# Altium schematic sheet Power.SchDoc
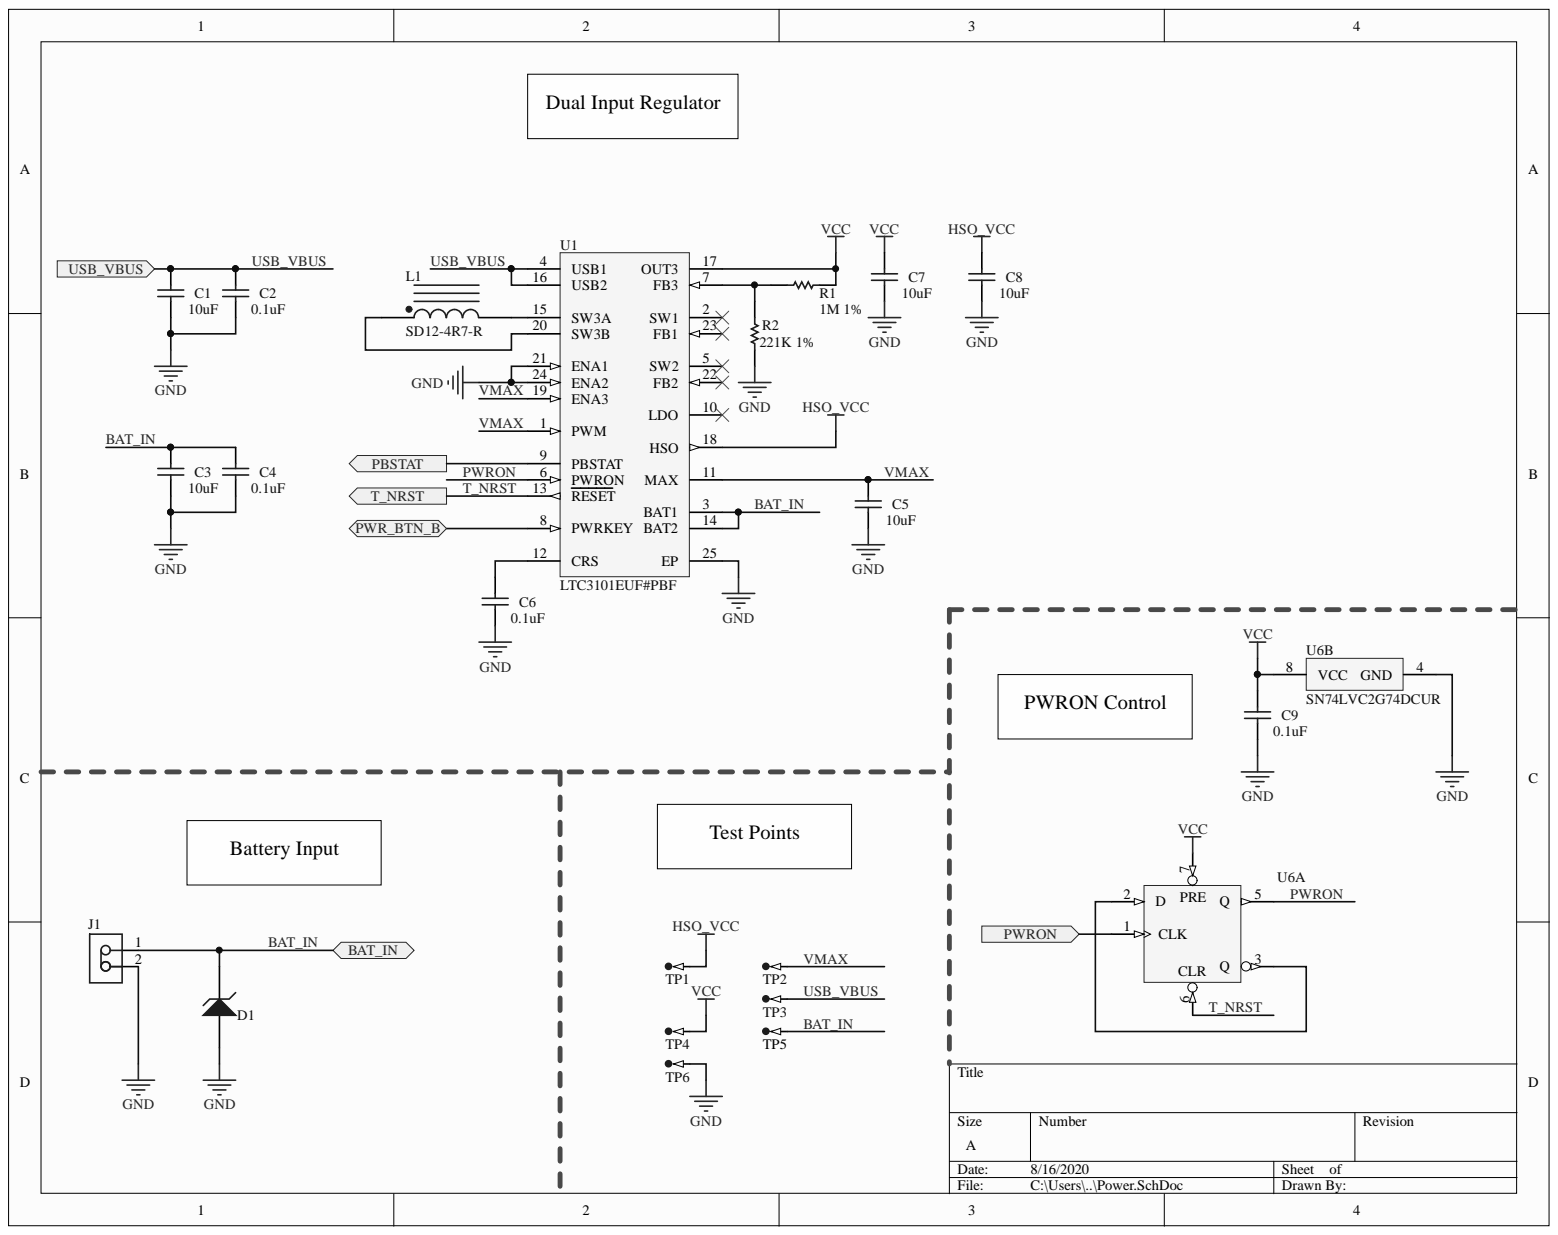
[diagram p0: Power.SchDoc]

## Parts
C1  10uF  [CAPC0603(1608)100_L]
C2  0.1uF  [CAPC0603(1608)100_L]
C3  10uF  [CAPC0603(1608)100_L]
C4  0.1uF  [CAPC0603(1608)100_L]
C5  10uF  [CAPC0603(1608)100_L]
C6  0.1uF  [CAPC0603(1608)100_L]
C7  10uF  [CAPC0603(1608)100_L]
C8  10uF  [CAPC0603(1608)100_L]
C9  0.1uF  [CAPC0603(1608)100_L]
D1  XGD10603MR  [TECO-0603_V]  (value not drawn)
J1  640456-2  [TECO-640456-2_V]  (value not drawn)
L1  SD12-4R7-R  [EATN-SD12_V]
R1  1M 1%  [RESC0603(1608)_N]
R2  221K 1%  [RESC0603(1608)_N]
TP1  TP-SM-C-100  [TP-SM-C-100]  (value not drawn)  (no BOM)
TP2  TP-SM-C-100  [TP-SM-C-100]  (value not drawn)  (no BOM)
TP3  TP-SM-C-100  [TP-SM-C-100]  (value not drawn)  (no BOM)
TP4  TP-SM-C-100  [TP-SM-C-100]  (value not drawn)  (no BOM)
TP5  TP-SM-C-100  [TP-SM-C-100]  (value not drawn)  (no BOM)
TP6  TP-SM-C-100  [TP-SM-C-100]  (value not drawn)  (no BOM)
U1  LTC3101EUF#PBF  [LT-UF-24_N]
U6  SN74LVC2G74DCUR  [DCU0008A_N]  (parts A,B)

## Ports
BAT_IN, PBSTAT, PWR_BTN_B, PWRON, T_NRST, USB_VBUS

## Nets
GND: C1.1 C2.2 C3.1 C4.2 C5.1 C6.2 C7.1 C8.1 C9.2 D1.1 J1.2 R2.1 TP6.1 U1.21(ENA1) U1.24(ENA2) U1.25(EP) U6.4(GND)
HSO_VCC: C8.2 TP1.1 U1.18(HSO)
VCC: C7.2 C9.1 R1.1 TP4.1 U1.17(OUT3) U6.7 U6.8(VCC)
BAT_IN: C3.2 C4.1 D1.2 J1.1 TP5.1 U1.3(BAT1) U1.14(BAT2) ; port BAT_IN
PBSTAT: U1.9(PBSTAT) ; port PBSTAT
PWR_BTN_B: U1.8(PWRKEY) ; port PWR_BTN_B
PWRON: U1.6(PWRON) U6.5(Q)
T_NRST: U1.13(RESET) U6.6 ; port T_NRST
USB_VBUS: C1.2 C2.1 TP3.1 U1.4(USB1) U1.16(USB2) ; port USB_VBUS
VMAX: C5.2 TP2.1 U1.1(PWM) U1.11(MAX) U1.19(ENA3)
NetC6_1: C6.1 U1.12(CRS)
NetL1_1: L1.1 U1.20(SW3B)
NetL1_2: L1.2 U1.15(SW3A)
NetR1_2: R1.2 R2.2 U1.7(FB3)
NetU1_2: U1.2(SW1)
NetU1_5: U1.5(SW2)
NetU1_10: U1.10(LDO)
NetU1_22: U1.22(FB2)
NetU1_23: U1.23(FB1)
NetU6_1: U6.1(CLK) ; port PWRON
NetU6_2: U6.2(D) U6.3(Q)

## Library data of the parts
C1-C9 = CMP-1035-00873-1: CAP 27pF 16V ±0.5pF 0603 (1608 Metric) Thickness 1mm SMD; Case-EIA: 0603; Case-Metric: 1608; Tolerance: Â±0.5pF; Component Kind: Standard; Library Name: Altium Content Vault; Max Thickness: 1 mm; Rated Voltage: 16 V; Technology: SMT; Value: 27pF
D1 = CMP-2000-06889-1: ESD Protector Overvoltage Protection Device, 0.25 pF, 24 V, -55 to 125 degC, 2-Pin SMD (0603), RoHS, Tape and Reel; Manufacturer: TE Connectivity; Capacitance Value: 0.25pF; Clamping Voltage: 45(Typ)V; Direction Type: Bi-Directional; Leakage Current: 10uA; Number of  Elements: 1; Product Depth (mm): 1(Max)mm; Product Height (mm): 0.55mm; Product Length (mm): 1.7(Max)mm; Rad Hardened: No; Reverse Stand-off Voltage: 24V; Component Kind: Standard; Datasheet URL: https://datasheet.ciiva.com/30563/eng-ss-scd27256-c-30563476.pdf; Datasheet Version: Rev. G, 11/2012; Leakage Current (Max): 10uA; Library Name: Altium Content Vault; Manufacturer URL: http://www.te.com/; Mounting: SurfaceMount; Mounting Style: SurfaceMount; Mounting Technology: SurfaceMount; Operating Temperature Classification: Military; Operating Temperature Max Deg. C: 125C; Operating Temperature Min Deg. C: -55C; Operating Temp Range: -55Cto125C; Package Description: 2-Pin Surface Mount Device, Body 1.60 x 0.825 mm; Package Reference: 0603; Packaging: TapeandReel/Box; Pin Count: 2; Working Voltage: 24V; Working Voltage (Max): 24V
J1 = CMP-2000-05417-1: Male Header, Pitch 2.54 mm, 1 x 2 Position, Height 10.49 mm, Tail Length 3.556 mm, -55 to 105 degC, RoHS, Bulk; Manufacturer: TE Connectivity; Agency Approvals: CSA,UL; Angle: Straight; Brand/Series: MTA-100Series; Color, Housing: White; Connector Type: MTA-100; Contact Centerline Spacing: 0.100 / in.; Contact Termination Area Plating: Tin; Current, Rating: 5 / A; Material, Contact: CopperAlloy; Material, Housing: Polyester; Mount Angle: Vertical; Mounting Hole Size: 0.04 / "; Number of Contacts: 2; Pin Spacing: 0.1 / "; Primary Type: InterconnectSystem; Special Features: Pinstrip; Standards: RoHSCompliant,ELVCompliant,UL94V-0; Temperature, Operating: -55to+105 / degC; Voltage, Rating: 250 / V; Color: White; Component Kind: Standard; Contact Mating Area Plating: Tin; Contact Plating: Tin; Contact Shape: Square; Datasheet URL: https://datasheet.ciiva.com/11746/0900766b80eb7fdd-11746365.pdf; Datasheet Version: Rev. W, 06/2004; Flammability Rating: UL94V-0; Length, Overall: 0.2 / "; Length, Pin: 0.14 / "; Length, Terminal Post: 0.14 / in.; Library Name: Altium Content Vault; Manufacturer URL: http://www.te.com/; Mounting Type: PCMount; Number of Positions: 2; Number of Rows: 1; Size, Post: 0.025 / in.; Termination: Solder; Type: PCBPlug
L1 = CMP-2000-07038-1: SD Series High Power Density, Low Profile, Shielded Inductor, 4.7 uH, -40 to 85 degC, 2-Pin SMD, RoHS, Tape and Reel; Manufacturer: Eaton; Brand: Coiltronics/Eaton; Core Material: Ferrite; Inductance: 4.7uH; Maximum DC Current: 1.29A; Maximum DC Resistance: 117.7mOhms; Test Frequency: 100kHz; Tolerance: 20%; Type: LowProfilePowerInductor; Unit Weight: 0.032000oz; Component Kind: Standard; Datasheet URL: http://datasheet.octopart.com/SD12-4R7-R-Coiltronics-datasheet-5402826.pdf; Datasheet Version: 03/2007; Factory Pack Quantity: 3800; Height: 1.2mm; Length: 5.2mm; Library Name: Altium Content Vault; Manufacturer URL: http://www.eaton.com/; Mounting Technology: SurfaceMount; Operating Temperature Range: -40Cto+85C; Package Description: 2-Pin Surface Mount Device, Body 5.2 x 5.2 mm; Package Reference: SD12; Packaging: Reel; Product: PowerInductors; Product Category: FixedInductors; RoHS: True; Series: SD; Shielding: Shielded; Termination Style: SMD/SMT; Width: 5.2mm
R1 R2 = CMP-1012-00001-1: Jumper 0603 (1608 Metric); Case-EIA: 0603; Case-Metric: 1608; Power: None; Value: 0.00 Ohm; Component Kind: Standard; Library Name: Altium Content Vault; Technology: SMT; Tolerance: None
TP1-TP6 = CMP-0484-00002-2: Test Point, Surface Mount, Circular, Pad Diameter 1 mm; Manufacturer: No Vendor; Library Name: Altium Content Vault; PartNumber: TP-SM-C-100
U1 = CMP-0478-00246-1: Wide Vin, Multi-Output DC/DC Converter and PowerPath Controller, 1.8 to 5.5 V Vin, 24-pin QFN (UF-24), -40 to 85 degC, Pb-Free; Manufacturer: Linear Technology; Number of Outputs: 3; Vin Max(V): 5.5; Vin Min(V): 1.8; Code_JEDEC: MO-220; Component Kind: Standard; ComponentLink1Description: Manufacturer URL; ComponentLink1URL: http://www.linear.com/; ComponentLink2Description: Datasheet; ComponentLink2URL: http://cds.linear.com/docs/en/datasheet/3101fb.pdf; ComponentLink3Description: Package Specification; ComponentLink3URL: http://cds.linear.com/docs/en/packaging/%28UF24%29%20QFN%2005-08-1697%20Rev%20B.pdf; DatasheetVersion: Rev. B; Library Name: Altium Content Vault; Mounting Technology: Surface Mount; PackageDescription: 24-Pin Plastic QFN, Body 4 x 4 mm, Pitch 0.5 mm; PackageReference: UF24; PackageVersion: Rev. B, 02/2012; PartNumber: LTC3101EUF#PBF; RoHS: TRUE
U6 = CMP-0859-00648-3: Single Positive-Edge-Triggered D-Type Flip-Flop with Clear and Preset, DCU0008A, LARGE T&R; Manufacturer: Texas Instruments; 3-State Output: No; Bits(#): 1; F @ Nom Voltage(Max)(Mhz): 150; ICC @ Nom Voltage(Max)(mA): 0.01; Input Type: CMOS/TTL; Logic: True; Output Drive (IOL/IOH)(Max)(mA): 32/-32; Output Type: CMOS; Rating: Catalog; Technology Family: LVC; tpd @ Nom Voltage(Max)(ns): 13.4,7.1,5.9,4.1; VCC(Max)(V): 5.5; VCC(Min)(V): 1.65; Voltage(Nom)(V): 1.8,2.5,3.3,5; Component Kind: Standard; DatasheetVersion: SCES203O; Generic Part Number: SN74LVC2G74; Library Name: Altium Content Vault; Operating Temperature Range(C): -40 to 125,-40 to 85; PackageReference: DCU0008A; Schmitt Trigger: No; Sub-Family: D-Type Flip-Flop
